# Altium schematic sheet 04_Inputs.SchDoc(04_Inputs)
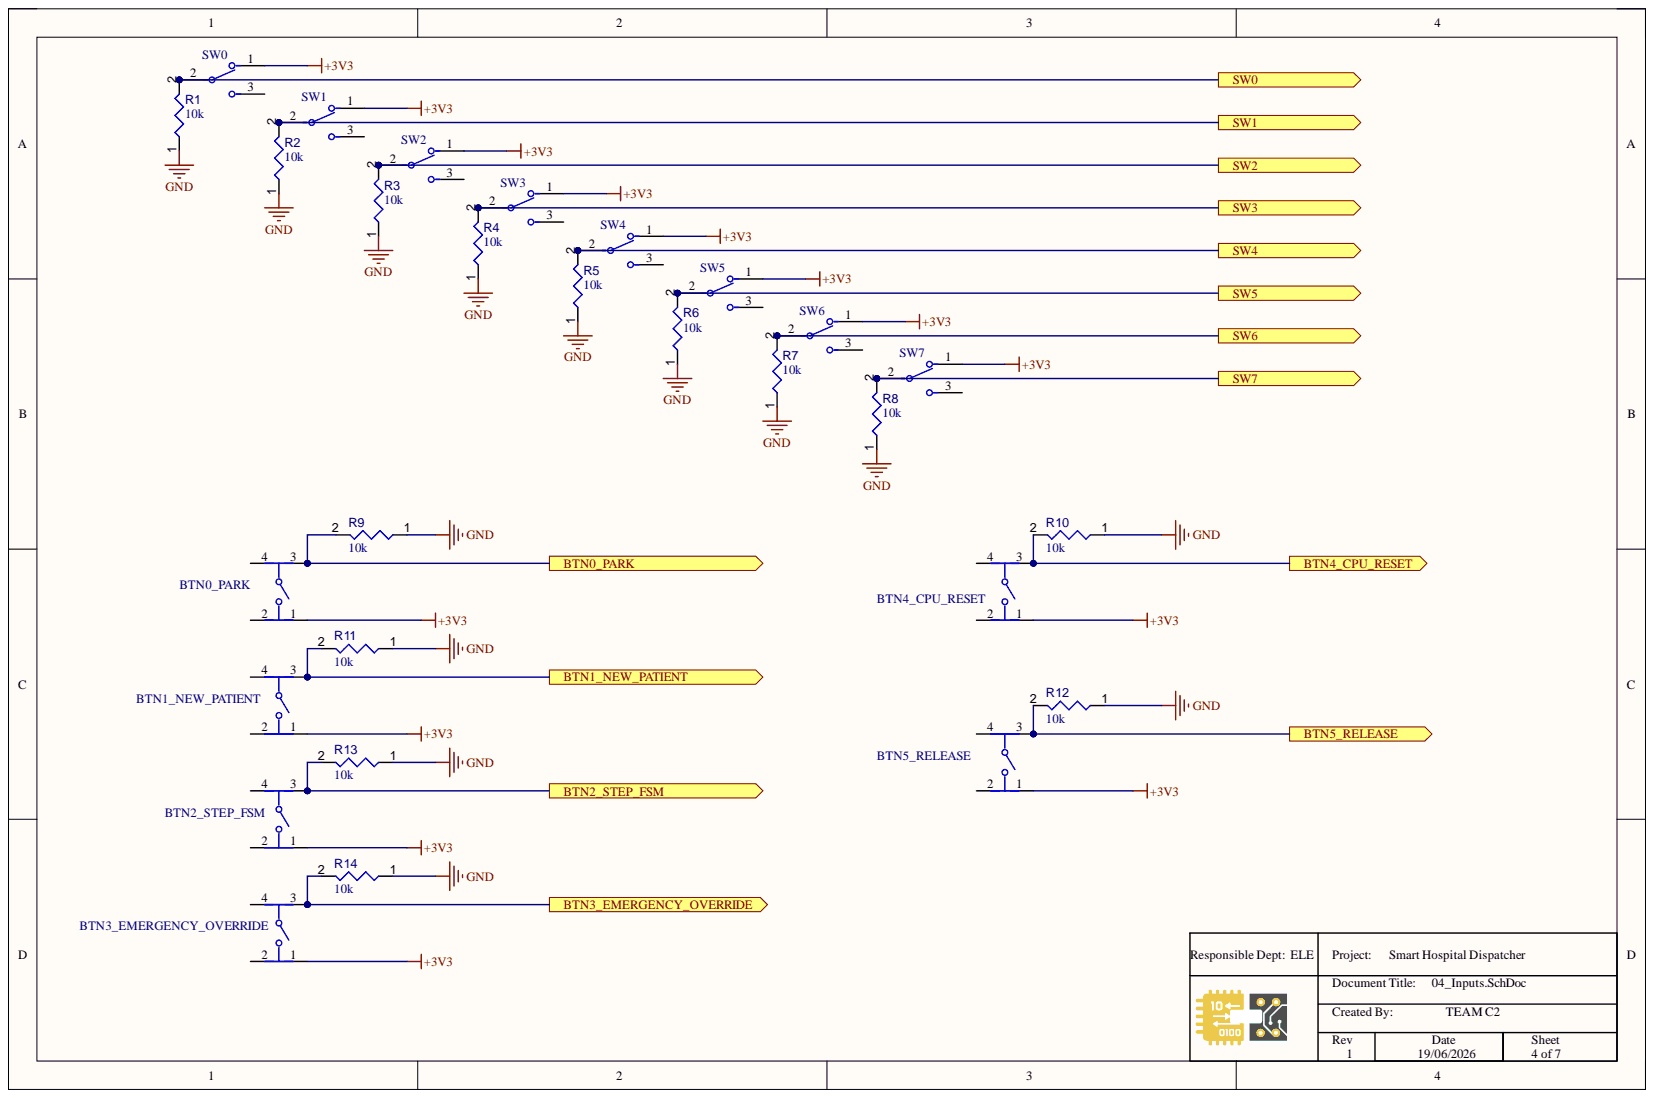
[diagram p0: 04_Inputs.SchDoc]

## Parts
BTN0_PARK  MJTP1230A  [FP-MJTP1230A-MFG]  (value not drawn)
BTN1_NEW_PATIENT  MJTP1230A  [FP-MJTP1230A-MFG]  (value not drawn)
BTN2_STEP_FSM  MJTP1230A  [FP-MJTP1230A-MFG]  (value not drawn)
BTN3_EMERGENCY_OVERRIDE  MJTP1230A  [FP-MJTP1230A-MFG]  (value not drawn)
BTN4_CPU_RESET  MJTP1230A  [FP-MJTP1230A-MFG]  (value not drawn)
BTN5_RELEASE  MJTP1230A  [FP-MJTP1230A-MFG]  (value not drawn)
R1  value 10k  [RESC0402(1005)_L]  (hidden comment: Resistor 10K  +/-1 % 0402 63 mW)
R2  value 10k  [RESC0402(1005)_L]  (hidden comment: Resistor 10K  +/-1 % 0402 63 mW)
R3  value 10k  [RESC0402(1005)_L]  (hidden comment: Resistor 10K  +/-1 % 0402 63 mW)
R4  value 10k  [RESC0402(1005)_L]  (hidden comment: Resistor 10K  +/-1 % 0402 63 mW)
R5  value 10k  [RESC0402(1005)_L]  (hidden comment: Resistor 10K  +/-1 % 0402 63 mW)
R6  value 10k  [RESC0402(1005)_L]  (hidden comment: Resistor 10K  +/-1 % 0402 63 mW)
R7  value 10k  [RESC0402(1005)_L]  (hidden comment: Resistor 10K  +/-1 % 0402 63 mW)
R8  value 10k  [RESC0402(1005)_L]  (hidden comment: Resistor 10K  +/-1 % 0402 63 mW)
R9  value 10k  [RESC0402(1005)_L]  (hidden comment: Resistor 10K  +/-1 % 0402 63 mW)
R10  value 10k  [RESC0402(1005)_L]  (hidden comment: Resistor 10K  +/-1 % 0402 63 mW)
R11  value 10k  [RESC0402(1005)_L]  (hidden comment: Resistor 10K  +/-1 % 0402 63 mW)
R12  value 10k  [RESC0402(1005)_L]  (hidden comment: Resistor 10K  +/-1 % 0402 63 mW)
R13  value 10k  [RESC0402(1005)_L]  (hidden comment: Resistor 10K  +/-1 % 0402 63 mW)
R14  value 10k  [RESC0402(1005)_L]  (hidden comment: Resistor 10K  +/-1 % 0402 63 mW)
SW0  25436NAH6  [FP-25436NAH6-MFG]  (value not drawn)
SW1  25436NAH6  [FP-25436NAH6-MFG]  (value not drawn)
SW2  25436NAH6  [FP-25436NAH6-MFG]  (value not drawn)
SW3  25436NAH6  [FP-25436NAH6-MFG]  (value not drawn)
SW4  25436NAH6  [FP-25436NAH6-MFG]  (value not drawn)
SW5  25436NAH6  [FP-25436NAH6-MFG]  (value not drawn)
SW6  25436NAH6  [FP-25436NAH6-MFG]  (value not drawn)
SW7  25436NAH6  [FP-25436NAH6-MFG]  (value not drawn)

## Ports
BTN0_PARK, BTN1_NEW_PATIENT, BTN2_STEP_FSM, BTN3_EMERGENCY_OVERRIDE, BTN4_CPU_RESET, BTN5_RELEASE, SW0, SW1, SW2, SW3, SW4, SW5, SW6, SW7

## Nets
+3V3: BTN0_PARK.1 BTN1_NEW_PATIENT.1 BTN2_STEP_FSM.1 BTN3_EMERGENCY_OVERRIDE.1 BTN4_CPU_RESET.1 BTN5_RELEASE.1 SW0.1 SW1.1 SW2.1 SW3.1 SW4.1 SW5.1 SW6.1 SW7.1
GND: R1.1 R2.1 R3.1 R4.1 R5.1 R6.1 R7.1 R8.1 R9.1 R10.1 R11.1 R12.1 R13.1 R14.1
BTN0_PARK: BTN0_PARK.3 R9.2 ; port BTN0_PARK
BTN1_NEW_PATIENT: BTN1_NEW_PATIENT.3 R11.2 ; port BTN1_NEW_PATIENT
BTN2_STEP_FSM: BTN2_STEP_FSM.3 R13.2 ; port BTN2_STEP_FSM
BTN3_EMERGENCY_OVERRIDE: BTN3_EMERGENCY_OVERRIDE.3 R14.2 ; port BTN3_EMERGENCY_OVERRIDE
BTN4_CPU_RESET: BTN4_CPU_RESET.3 R10.2 ; port BTN4_CPU_RESET
BTN5_RELEASE: BTN5_RELEASE.3 R12.2 ; port BTN5_RELEASE
SW0: R1.2 SW0.2 ; port SW0
SW1: R2.2 SW1.2 ; port SW1
SW2: R3.2 SW2.2 ; port SW2
SW3: R4.2 SW3.2 ; port SW3
SW4: R5.2 SW4.2 ; port SW4
SW5: R6.2 SW5.2 ; port SW5
SW6: R7.2 SW6.2 ; port SW6
SW7: R8.2 SW7.2 ; port SW7

Not connected: BTN0_PARK 2 4; BTN1_NEW_PATIENT 2 4; BTN2_STEP_FSM 2 4; BTN3_EMERGENCY_OVERRIDE 2 4; BTN4_CPU_RESET 2 4; BTN5_RELEASE 2 4; SW0 3; SW1 3; SW2 3; SW3 3; SW4 3; SW5 3; SW6 3; SW7 3

## Library data of the parts
BTN0_PARK BTN1_NEW_PATIENT BTN2_STEP_FSM BTN3_EMERGENCY_OVERRIDE BTN4_CPU_RESET BTN5_RELEASE = CMP-47024-000004-1: SWITCH TACTILE SPST-NO 0.05A 12V; Actuator Color: Black; Actuator Length: 1.4mm; Actuator Material: Thermoplastic; Actuator Type: Standard; Contact Resistance: 100mR; Current Rating: 50mA; Electrical Life: 100000Cycles; Illumination Type: Non-Illuminated; Operating Force: 160gf; Switch Function: Off-Mom; Switch Travel: 0.25mm; Throw Configuration: SPST; Color: Black; Component Kind: Standard; Contact Material: Bronze; Contact Plating: Silver; Library Name: Altium Content Vault; Max Operating Temperature: 70°C; Max Voltage Rating (DC): 12V; Mechanical Life: 100000Cycles; Min Operating Temperature: -20°C; Mount: Through Hole; Orientation: Straight; Package Quantity: 2000; Packaging: Bulk; REACH SVHC: No SVHC; RoHS Compliant: Yes; Series: MJTP; Termination: PC Pin; Voltage Rating (DC): 12V
  BTN2_STEP_FSM: ComponentLink1Description: New link; ComponentLink2Description: New link 1
R1-R14 = CMP-009-00149-3: Chip Resistor, 10 kOhms, +/-1 %, 63 mW, -55 to 125 degC, 0402; Power: 63mW; Tolerance: 1%; Case/Package: 0402; Component Kind: Standard; Max Operating Temperature: 155°C; Min Operating Temperature: -55°C; Pins: 2; Library Name: BERTRAND MUNGU CHO
SW0-SW7 = CMP-47022-000005-1: SWITCH SLIDE SPDT 4A 125V; Actuator Length: 6mm; Actuator Material: Nylon; Actuator Type: Standard; Contact Resistance: 10mR; Current Rating: 1A; Electrical Life: 10000Cycles; Max Voltage Rating (AC): 250V; Max Voltage Rating (DC): 30V; Mechanical Life: 20000Cycles; Switch Function: On-On; Switch Travel: 4mm; Component Kind: Standard; Contact Material: Silver; Library Name: Altium Content Vault; Max Operating Temperature: 85°C; Min Operating Temperature: -40°C; Mount: Through Hole; Orientation: Straight; Package Quantity: 100; Packaging: Bulk; Series: 25000N; Termination: PC Pin; Throw Configuration: SPDT; Voltage Rating (AC): 125V; Voltage Rating (DC): 30V
